# Altium schematic sheet 04_NOTECARD-CONNECTION.SchDoc
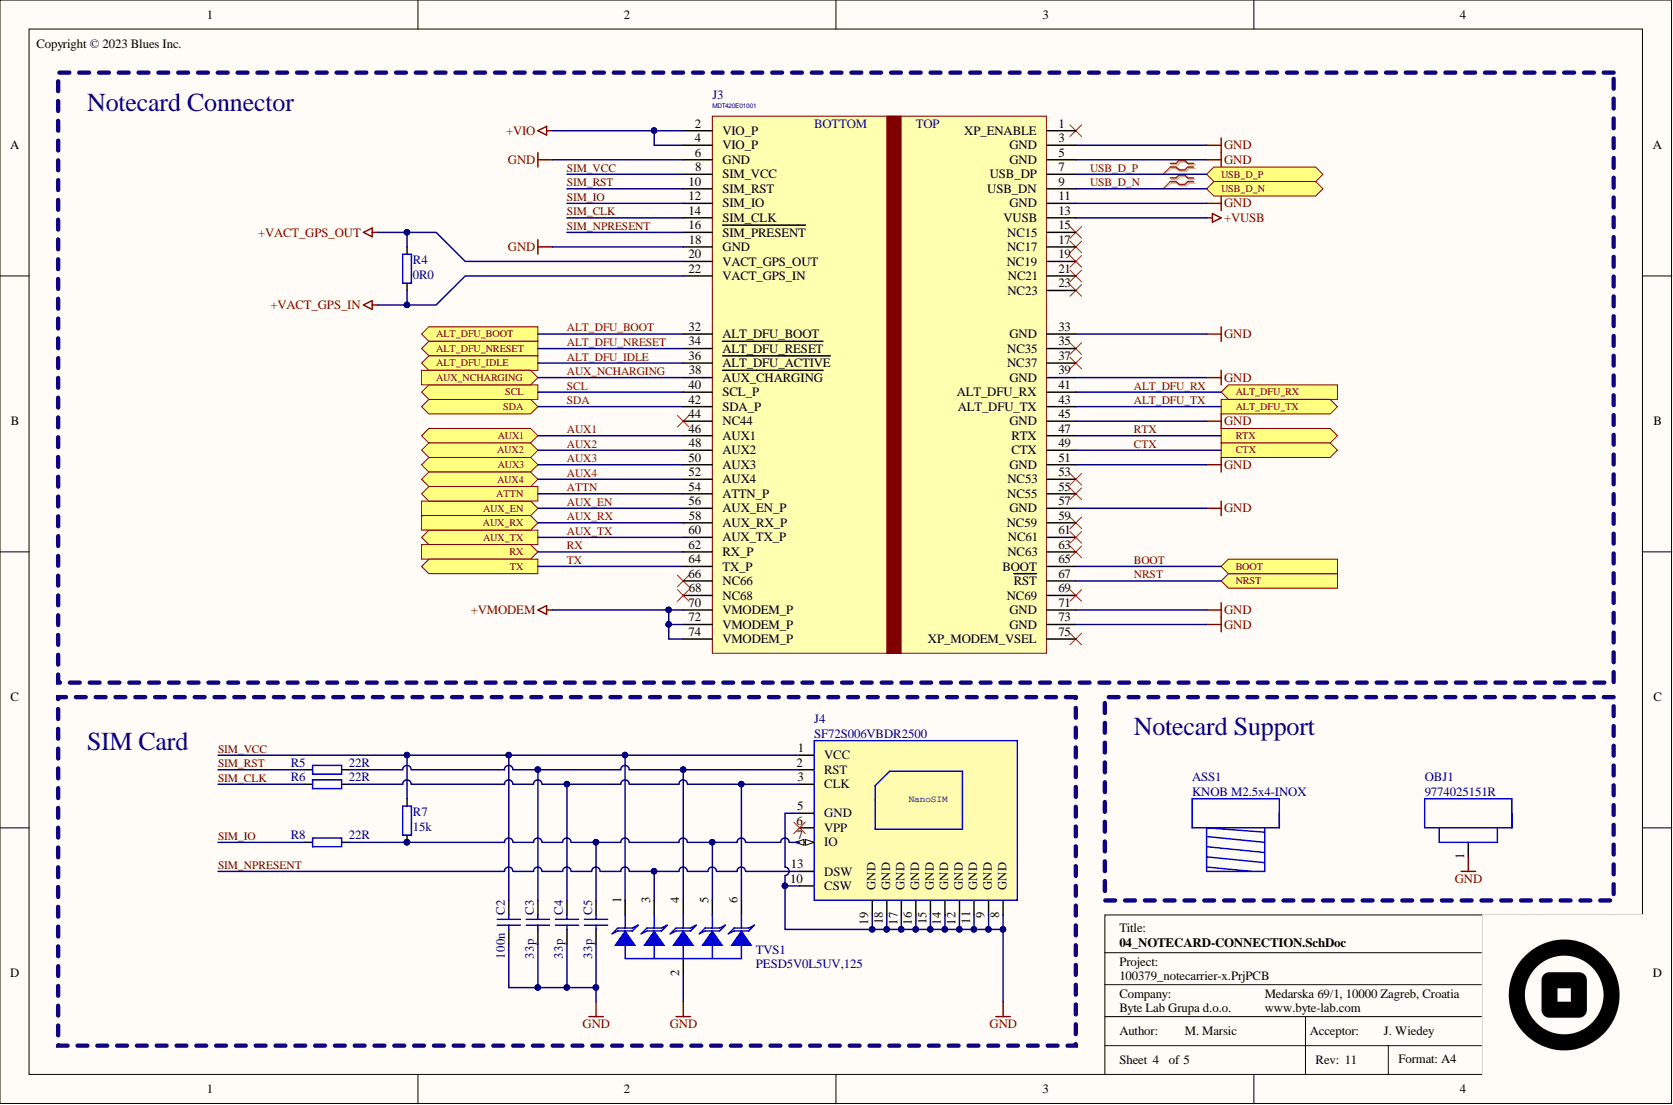
[diagram p0: 04_NOTECARD-CONNECTION.SchDoc]

## Parts
ASS1  KNOB M2.5x4-INOX  (mechanical)
C2  100n  [C-0402]
C3  33p  [C-0402]
C4  33p  [C-0402]
C5  33p  [C-0402]
J3  MDT420E01001  [J-75-0050-MOS-M2]
J4  SF72S006VBDR2500  [J-NANOSIM-SF72S006VBA]
OBJ1  9774025151R  [DIST-WASMSIM0250]
R4  0R0  [R-0603]
R5  22R  [R-0402]
R6  22R  [R-0402]
R7  15k  [R-0402]
R8  22R  [R-0402]
TVS1  PESD5V0L5UV,125  [SOT666]

## Ports
ALT_DFU_BOOT, ALT_DFU_IDLE, ALT_DFU_NRESET, ALT_DFU_RX, ALT_DFU_TX, ATTN, AUX1, AUX2, AUX3, AUX4, AUX_EN, AUX_NCHARGING, AUX_RX, AUX_TX, BOOT, CTX, NRST, RTX, RX, SCL, SDA, TX, USB_D_N, USB_D_P

## Nets
+VACT_GPS_IN: J3.22(VACT_GPS_IN) R4.1
+VACT_GPS_OUT: J3.20(VACT_GPS_OUT) R4.2
+VIO: J3.2(VIO_P) J3.4(VIO_P)
+VMODEM: J3.70(VMODEM_P) J3.72(VMODEM_P) J3.74(VMODEM_P)
+VUSB: J3.13(VUSB)
GND: C2.1 C3.1 C4.1 C5.1 J3.3(GND) J3.5(GND) J3.6(GND) J3.11(GND) J3.18(GND) J3.33(GND) J3.39(GND) J3.45(GND) J3.51(GND) J3.57(GND) J3.71(GND) J3.73(GND) J4.5(GND) J4.8(GND) J4.9(GND) J4.10(CSW) J4.11(GND) J4.12(GND) J4.14(GND) J4.15(GND) J4.16(GND) J4.17(GND) J4.18(GND) J4.19(GND) OBJ1.1 TVS1.2
ALT_DFU_BOOT: J3.32(ALT_DFU_BOOT) ; port ALT_DFU_BOOT
ALT_DFU_IDLE: J3.36(ALT_DFU_ACTIVE) ; port ALT_DFU_IDLE
ALT_DFU_NRESET: J3.34(ALT_DFU_RESET) ; port ALT_DFU_NRESET
ALT_DFU_RX: J3.41(ALT_DFU_RX) ; port ALT_DFU_RX
ALT_DFU_TX: J3.43(ALT_DFU_TX) ; port ALT_DFU_TX
ATTN: J3.54(ATTN_P) ; port ATTN
AUX1: J3.46(AUX1) ; port AUX1
AUX2: J3.48(AUX2) ; port AUX2
AUX3: J3.50(AUX3) ; port AUX3
AUX4: J3.52(AUX4) ; port AUX4
AUX_EN: J3.56(AUX_EN_P) ; port AUX_EN
AUX_NCHARGING: J3.38(AUX_CHARGING) ; port AUX_NCHARGING
AUX_RX: J3.58(AUX_RX_P) ; port AUX_RX
AUX_TX: J3.60(AUX_TX_P) ; port AUX_TX
BOOT: J3.65(BOOT) ; port BOOT
CTX: J3.49(CTX) ; port CTX
NRST: J3.67(RST) ; port NRST
RTX: J3.47(RTX) ; port RTX
RX: J3.62(RX_P) ; port RX
SCL: J3.40(SCL_P) ; port SCL
SDA: J3.42(SDA_P) ; port SDA
SIM_CLK: J3.14(SIM_CLK) R6.1
SIM_IO: J3.12(SIM_IO) R8.1
SIM_NPRESENT: J3.16(SIM_PRESENT) J4.13(DSW) TVS1.3
SIM_RST: J3.10(SIM_RST) R5.1
SIM_VCC: C2.2 J3.8(SIM_VCC) J4.1(VCC) R7.2 TVS1.1
TX: J3.64(TX_P) ; port TX
USB_D_N: J3.9(USB_DN) ; port USB_D_N
USB_D_P: J3.7(USB_DP) ; port USB_D_P
NetC3_2: C3.2 J4.2(RST) R5.2 TVS1.4
NetC4_2: C4.2 J4.3(CLK) R6.2 TVS1.6
NetC5_2: C5.2 J4.7(IO) R7.1 R8.2 TVS1.5

Not connected: J3 1(XP_ENABLE) 15(NC15) 17(NC17) 19(NC19) 21(NC21) 23(NC23) 35(NC35) 37(NC37) 44(NC44) 53(NC53) 55(NC55) 59(NC59) 61(NC61) 63(NC63) 66(NC66) 68(NC68) 69(NC69) 75(XP_MODEM_VSEL); J4 6(VPP)

## Library data of the parts
ASS1 = KNOB - M2.5x4-INOX: VITE TCEI 2.5X4 ESAGONO INCASSATO A2 INOX; BL Part Number: 6000-168; Manufacturer: KRAFTBERG; Manufacturer Part Number: M2.5X4/D7985-A2; Drawn_By: Blues Wireless; Library Name: n21-p2.SchLib; Supplier 1: TME; Supplier Part Number 1: M2.5X4/D7985-A2
C2 = C0402-100n-16V-X7R: Capacitor ceramic 100n ±10% X7R 16V 0402; BL Part Number: 2000-908; Checked_By: Dodig; Drawn_By: Puskar; Component Kind: Standard; DateModified: 27-08-2013; Library Name: BL_Passive.SchLib; Manufacturer: N/A; Manufacturer Part Number: N/A; Simulation: CAP; Supplier 1: Digi-Key; Supplier Part Number 1: 490-3261-1-ND
C3-C5 = C0402-33p-50V-C0G-5: Capacitor ceramic 33p ±5% C0G 50V 0402; BL Part Number: 2001-377; Checked_By: Dodig; Drawn_By: Puskar; Component Kind: Standard; DateModified: 24-11-2013; Library Name: BL_Passive.SchLib; Manufacturer: N/A; Manufacturer Part Number: N/A; Simulation: CAP; Supplier 1: Digi-Key; Supplier Part Number 1: 1276-1272-1-ND
J3 = AMPHENOL-75 - M-2 KEY E_13: CONN. M.2 75P M/90° P=0.5 MDT420E01001 AMPHENOL SMT; BL Part Number: 2007-460; Manufacturer: Amphenol ICC (FCI); Manufacturer Part Number: MDT420E03001; Drawn_By: Blues Wireless; Component Kind: Standard; Library Name: n21-p2.SchLib; Supplier 1: Digi-Key; Supplier Part Number 1: MDT420E03001CT-ND
J4 = NANOSIM - J-NANOSIM-SF72S006VBA: CONN. NANOSIM 8P F/90° PUSH-PUSH SF72S006VBAR2500 JAE ELECTRONICS SMT; BL Part Number: 2007-458; Drawn_By: Blues Wireless; Component Kind: Standard; Library Name: n21-p2.SchLib; Manufacturer: JAE Electronics; Manufacturer Part Number: SF72S006VBDR2500; Supplier 1: Digi-Key; Supplier Part Number 1: 670-2967-1-ND
OBJ1 = DISTANZIALE - M2.5x0250: DISTANZ. CIL. METALLO 5.1X2.5 9774025151R W.E SMT; BL Part Number: 6020-113; Manufacturer: Würth Elektronik; Drawn_By: Blues Wieless; Component Kind: Standard; Library Name: n21-p2.SchLib; Manufacturer Part Number: 9774025151R; Supplier 1: Digi-Key; Supplier Part Number 1: 732-7078-1-ND
R4 = R0603-0R0: Resistor 0R0 0603; BL Part Number: 2000-723; Checked_By: Puskar; Drawn_By: Dodig; Component Kind: Standard; DateModified: 07-12-2013; Library Name: BL_Passive.SchLib; Manufacturer: N/A; Manufacturer Part Number: N/A; Supplier 1: Digi-Key; Supplier Part Number 1: 311-0.0GRCT-ND
R5 R6 R8 = R0402-22R-1: Resistor 22R ±1% 0402; BL Part Number: 2001-170; Checked_By: Hamin; Drawn_By: Zvonc; Component Kind: Standard; DateModified: 13-02-2021; Library Name: BL_Passive.SchLib; Manufacturer: N/A; Manufacturer Part Number: N/A; Supplier 1: Digi-Key; Supplier Part Number 1: 311-22.0LRCT-ND
R7 = R0402-15k-1: Resistor 15k ±1% 0402; BL Part Number: 2001-157; Checked_By: Zvonc; Drawn_By: Puskar; Component Kind: Standard; DateModified: 23-01-2015; Library Name: BL_Passive.SchLib; Manufacturer: N/A; Manufacturer Part Number: N/A; Supplier 1: Digi-Key; Supplier Part Number 1: P15.0KLCT-ND
TVS1 = PESD5V0L5UV,125: PESD Series 25 W 5 V Uni-Directional Fivefold ESD Protection Diode Array SOT-666; BL Part Number: 2007-452; Manufacturer: Nexperia; Drawn_By: Blues Wireless; Component Kind: Standard; Library Name: n21-p2.SchLib; Manufacturer Part Number: PESD5V0L5UV,125; Supplier 1: Digi-Key; Supplier Part Number 1: 1727-5797-1-ND
